# Altium schematic sheet 02_NRF52832.SchDoc
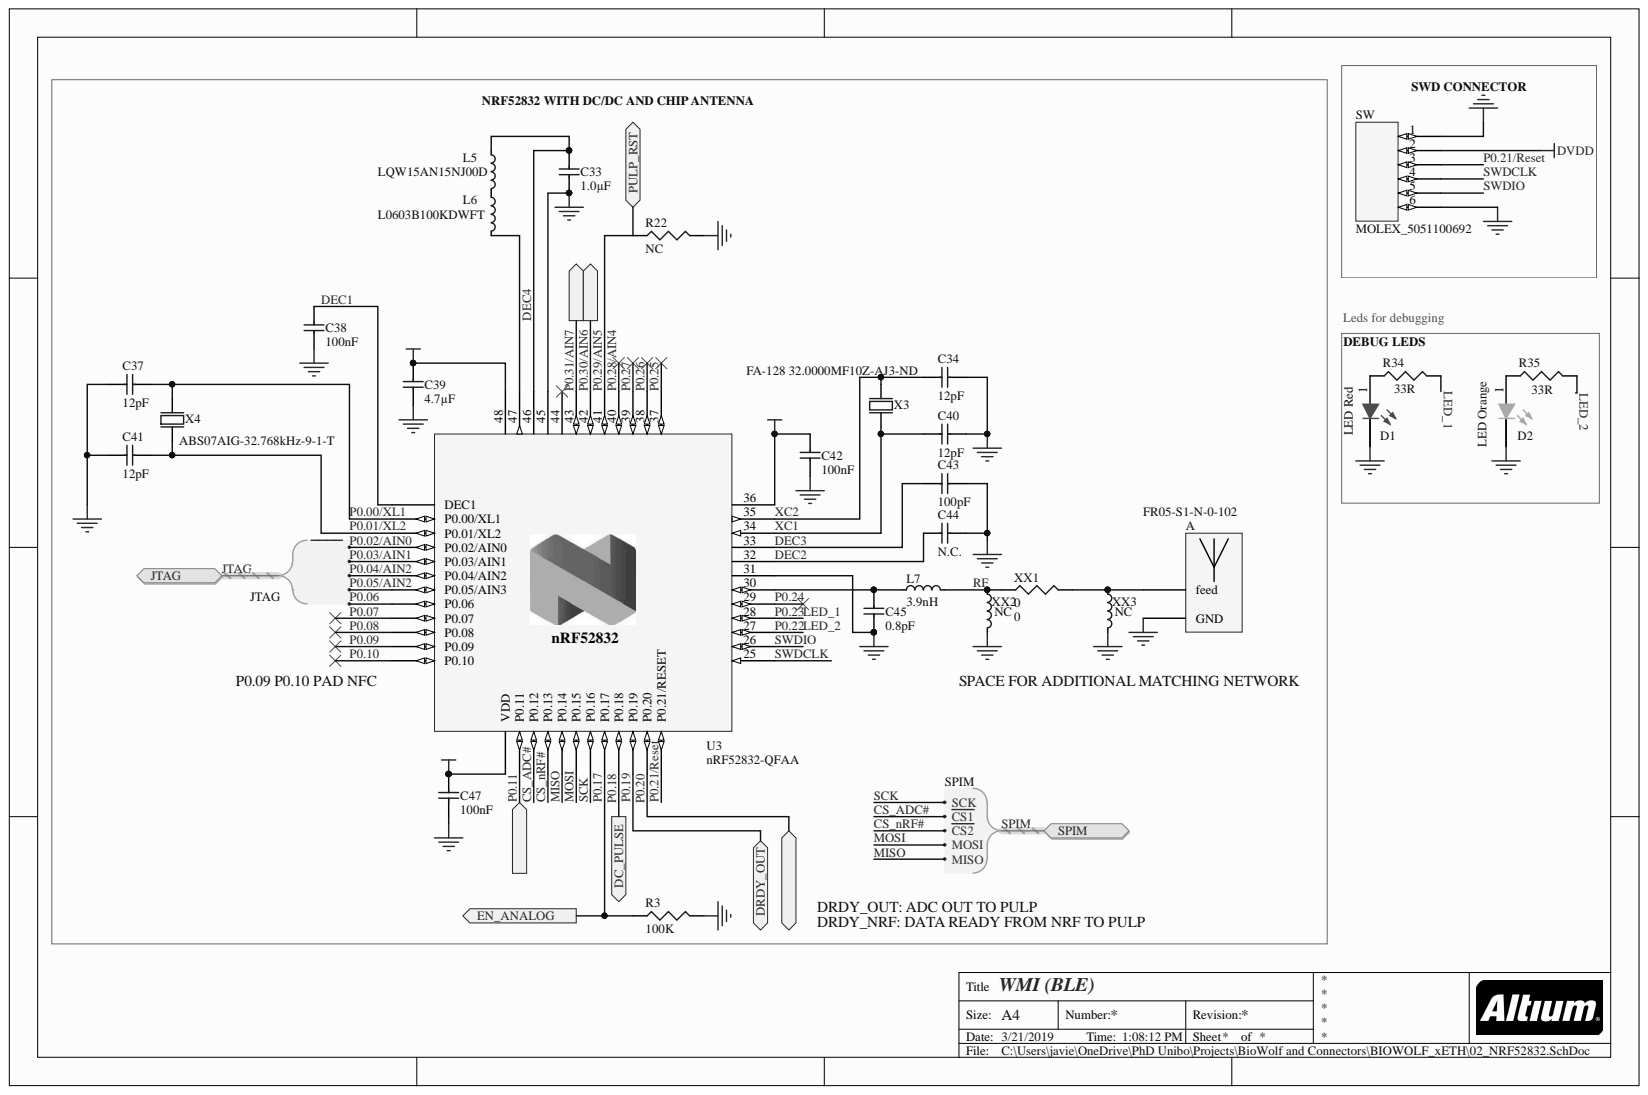
[diagram p0: 02_NRF52832.SchDoc]

## Parts
A  FR05-S1-N-0-102  [DFN510P700X220-2N]
C33  1.0µF  [CAPC1608X06L]
C34  12pF  [CAPC1005X04L]
C37  12pF  [CAPC1005X04L]
C38  100nF  [CAPC1005X04L]
C39  4.7µF  [CAPC1608X06L]
C40  12pF  [CAPC1005X04L]
C41  12pF  [CAPC1005X04L]
C42  100nF  [CAPC1005X04L]
C43  100pF  [CAPC1005X04L]
C44  N.C.  [CAPC1005X04L]
C45  0.8pF  [CAPC1005X04L]
C47  100nF  [CAPC1005X04L]
D1  LED Red  [DIOC1608X08L]
D2  LED Orange  [DIOC1608X08L]
L5  LQW15AN15NJ00D  [INDC1005X04L]
L6  L0603B100KDWFT  [J1-0603]
L7  3.9nH  [INDC1005X04L]
R3  100K  [0402]
R34  value 33R  [J1-0603]
R35  value 33R  [J1-0603]
SW  MOLEX_5051100692  [MOLEX_5051100692]
U3  nRF52832-QFAA  [QFN40P600X600X90-48N]
X3  FA-128 32.0000MF10Z-AJ3-ND  [BT-XTAL_2016]
X4  ABS07AIG-32.768kHz-9-1-T  [XTAL_3215]
XX1  0  [0402]
XX2  NC  [INDC1005X04L]
XX3  NC  [INDC1005X04L]

## Ports
DC_PULSE, DRDY#, DRDY_NRF, DRDY_OUT, EN_ANALOG, JTAG, JTAG.TCK, JTAG.TDI, JTAG.TDO, JTAG.TMS, JTAG.~{TRST}, PULP_RST, SCL, SDA, SPIM, SPIM.MISO, SPIM.MOSI, SPIM.SCK, SPIM.~{CS1}, SPIM.~{CS2}

## Nets
DVDD: C39.1 C42.1 C47.1 SW.2 U3.13(VDD) U3.36 U3.48
GND: A.3 C33.1 C34.2 C37.2 C38.2 C39.2 C40.2 C41.2 C42.2 C43.2 C44.1 C45.1 C47.2 D1.2 D2.2 R3.2 SW.1 SW.6 U3.31 U3.45 U3.49 X3.2 X3.4 XX2.2 XX3.2
CS_ADC#: U3.15(P0.12) ; port SPIM
CS_nRF#: U3.16(P0.13) ; port SPIM
DEC1: C38.1 U3.1(DEC1)
DEC2: C44.2 U3.32
DEC3: C43.1 U3.33
DEC4: C33.2 L5.1 U3.46
JTAG.~{TRST}: U3.4(P0.02/AIN0) ; port JTAG
JTAG.TCK: U3.8(P0.06) ; port JTAG
JTAG.TDI: U3.5(P0.03/AIN1) ; port JTAG
JTAG.TDO: U3.6(P0.04/AIN2) ; port JTAG
JTAG.TMS: U3.7(P0.05/AIN3) ; port JTAG
LED_1: R34.2 U3.28
LED_2: R35.2 U3.27
MISO: U3.17(P0.14) ; port SPIM
MOSI: U3.18(P0.15) ; port SPIM
P0.00/XL1: C37.1 U3.2(P0.00/XL1) X4.1
P0.01/XL2: C41.1 U3.3(P0.01/XL2) X4.2
P0.07: U3.9(P0.07)
P0.08: U3.10(P0.08)
P0.09: U3.11(P0.09)
P0.10: U3.12(P0.10)
P0.11: U3.14(P0.11) ; port DRDY#
P0.17: R3.1 U3.20(P0.17) ; port EN_ANALOG
P0.18: U3.21(P0.18) ; port DC_PULSE
P0.19: U3.22(P0.19) ; port DRDY_OUT
P0.20: U3.23(P0.20) ; port DRDY_NRF
P0.21/Reset: SW.3 U3.24(P0.21/RESET)
P0.24: U3.29
P0.25: U3.37
P0.26: U3.38
P0.27: U3.39
P0.28/AIN4: U3.40
P0.29/AIN5: U3.41 ; port PULP_RST
P0.30/AIN6: U3.42 ; port SDA
P0.31/AIN7: U3.43 ; port SCL
RF: L7.2 XX1.1 XX2.1
SCK: U3.19(P0.16) ; port SPIM
SWDCLK: SW.4 U3.25
SWDIO: SW.5 U3.26
XC1: C40.1 U3.34 X3.1
XC2: C34.1 U3.35 X3.3
NetA_1: A.1 XX1.2 XX3.1
NetC45_2: C45.2 L7.1 U3.30
NetD1_1: D1.1 R34.1
NetD2_1: D2.1 R35.1
NetL5_2: L5.2 L6.1
NetL6_2: L6.2 U3.47

Not connected: U3 44

## Library data of the parts
A = FR05-S1-N-0-102: Bluetooth antenna; Component Kind: Standard; Library Name: SchematicLibrary.SchLib
C33 = C0603-1µ0: Capacitor, X7R, ±10%; Artikkelnr Noca: 20039335; Artikkelnr Simpro: N.A.; Database Table Name: Capacitors$; Note: 16V; Component Kind: Standard; Footprint Doc: 0603; LatestRevisionDate: 2013-06-05; Library Name: Nordic Passive DB.DbLib; Part Number: C0603-1µ0; Pin Count: 2; Published: 2009-05-08; Value: 1.0µF; Publisher: Nordic Semiconductor
C34 C37 C40 C41 = C0402-12p: Capacitor, NP0, ±2%; Artikkelnr Noca: 20029159; Artikkelnr Simpro: N.A.; Database Table Name: Capacitors$; Note: 50V; Component Kind: Standard; Footprint Doc: 0402; LatestRevisionDate: 2011-05-11; Library Name: Nordic Passive DB.DbLib; Part Number: C0402-12p; Pin Count: 2; Published: 2009-05-08; Value: 12pF; Publisher: Nordic Semiconductor
C38 C42 C47 = C0402-100n: Capacitor, X7R, ±10%; Artikkelnr Noca: 20039200; Artikkelnr Simpro: N.A.; Database Table Name: Capacitors$; Note: 16V; Component Kind: Standard; Footprint Doc: 0402; LatestRevisionDate: 2009-11-23; Library Name: Nordic Passive DB.DbLib; Part Number: C0402-100n; Pin Count: 2; Published: 2009-05-08; Value: 100nF; Publisher: Nordic Semiconductor
C39 = C0603-4µ7: Capacitor, X5R, ±10%; Artikkelnr Noca: 20069060; Artikkelnr Simpro: N.A.; Database Table Name: Capacitors$; Note: 6.3V; Component Kind: Standard; Footprint Doc: 0603; LatestRevisionDate: 2009-11-23; Library Name: Nordic Passive DB.DbLib; Part Number: C0603-4µ7; Pin Count: 2; Published: 2009-05-08; Value: 4.7µF; Publisher: Nordic Semiconductor
C43 = C0402-100p: Capacitor, NP0, ±5%; Artikkelnr Noca: 20029135; Artikkelnr Simpro: N.A.; Database Table Name: Capacitors$; Note: 50V; Component Kind: Standard; Footprint Doc: 0402; LatestRevisionDate: 2009-05-08; Library Name: Nordic Passive DB.DbLib; Part Number: C0402-100p; Pin Count: 2; Published: 2009-05-08; Value: 100pF; Publisher: Nordic Semiconductor
C44 = C0402-N.C.: Not mounted; Artikkelnr Noca: N.A.; Database Table Name: Capacitors$; Note: Chip Cap; Artikkelnr Simpro: N.A.; Component Kind: Standard; Footprint Doc: 0402; LatestRevisionDate: 2009-05-08; Library Name: Nordic Passive DB.DbLib; Part Number: C0402-N.C.; Pin Count: 2; Published: 2009-05-08; Value: N.C.; Publisher: Nordic Semiconductor
C45 = C0402-0.8p: Capacitor, NP0, ±5%; Artikkelnr Noca: 20029147; Artikkelnr Simpro: N.A.; Database Table Name: Capacitors$; Note: 50V; Component Kind: Standard; Footprint Doc: 0402; LatestRevisionDate: 2012-11-21; Library Name: Nordic Passive DB.DbLib; Part Number: C0402-0.8p; Pin Count: 2; Published: 2009-05-08; Value: 0.8pF; Publisher: Nordic Semiconductor
D1 = KING-LED-RED-APT1608LSECK/J3-PRV: 1.6X0.8mm SMD CHIP LED LAMP, Hyper Red; Part Number: APT1608LSECK/J4-PRV; Forward Voltage: 1.8V; Test Current: 2mA; Component Kind: Standard; ComponentLink1Description: Datasheet; ComponentLink1URL: http://www.kingbrightusa.com/images/catalog/SPEC/APT1608LSECK-J3-PRV.pdf; Library Name: schematics.SchLib; Supplier 1: Digi-Key; Supplier 2: Mouser; Supplier 3: Digi-Key; Supplier 4: Digi-Key; Supplier Part Number 1: 754-1930-6-ND; Supplier Part Number 2: 604-APT1608LSECKJ3RV; Supplier Part Number 3: 754-1930-1-ND; Supplier Part Number 4: 754-1930-2-ND
D2 = KING-LED-ORANGE-APT1608LSECK/J4-PRV: 1.6X0.8mm SMD CHIP LED LAMP, Super Bright Orange; Forward Voltage: 1.8V; Test Current: 2mA; Component Kind: Standard; ComponentLink1Description: Datasheet; ComponentLink1URL: http://www.kingbrightusa.com/images/catalog/SPEC/APT1608LSECK-J4-PRV.pdf; Library Name: schematics.SchLib; Part Number: APT1608LSECK/J4-PRV; Supplier 1: Digi-Key; Supplier 2: Digi-Key; Supplier 3: Mouser; Supplier 4: Digi-Key; Supplier Part Number 1: 754-1931-6-ND; Supplier Part Number 2: 754-1931-1-ND; Supplier Part Number 3: 604-APT1608LSECKJ4RV; Supplier Part Number 4: 754-1931-2-ND
L5 = L0402-15n: High frequency chip inductor ±10%; 2nd source part number: 658-1328; Manufacturer: Taiyo Yuden; Manufacturer Part Number: HK100515NJ-T; Artikkelnr Noca: 33029323; Artikkelnr Simpro: N.A.; Database Table Name: Inductors$; Value: 15nH; 2nd source Supplier: Farnell; Component Kind: Standard; Datasheet: http://www.yuden.co.jp/productdata/catalog/en/mlci04_e.pdf; Footprint Doc: 0402; LatestRevisionDate: 2012-09-19; Library Name: Nordic Passive DB.DbLib; Note: High frequency chip inductor ±10%; Part Number: L0402-15n; Pin Count: 2; Published: 2010-07-12; Supplier: Digi-Key; Supplier part number: 587-1521-1-ND; Publisher: Nordic Semiconductor
L6 = L0603-10µ: Chip inductor, IDC,min = 50 mA, ±20%; 2nd source part number: 178-6105; Manufacturer: Taiyo Yuden; Manufacturer Part Number: LBMF1608T100K; Artikkelnr Noca: 33029314; Artikkelnr Simpro: N.A.; Database Table Name: Inductors$; Note: LBMF1608T100K 10µH 80mA 0603; Value: 10µH; 2nd source Supplier: Farnell; Component Kind: Standard; Datasheet: http://www.yuden.co.jp/ut/product/pdf/elb2_e.pdf; Footprint Doc: 0603; LatestRevisionDate: 2012-09-19; Library Name: Nordic Passive DB.DbLib; Part Number: L0603-10µ; Pin Count: 2; Published: 2010-02-24; Supplier: Digi-Key; Supplier part number: 587-1714-1-ND; Publisher: Nordic Semiconductor
L7 = L0402-3n9: High frequency chip inductor ±5%; Manufacturer: Murata; Manufacturer Part Number: LQP15MN3N9B02D; Artikkelnr Noca: 33029151; Artikkelnr Simpro: N.A.; Database Table Name: Inductors$; Component Kind: Standard; Datasheet: http://search.murata.co.jp/Ceramy/image/img/PDF/ENG/L0075S0084LQP15M.pdf; Footprint Doc: 0402; LatestRevisionDate: 2012-09-19; Library Name: Nordic Passive DB.DbLib; Note: High frequency chip inductor ±5%; Part Number: L0402-3n9; Pin Count: 2; Published: 2009-05-08; Supplier: Digi-Key; Supplier part number: 490-1131-1-ND; Value: 3.9nH; Publisher: Nordic Semiconductor
R3 XX1 = Res3: Resistor; Component Kind: Standard; LatestRevisionDate: 29-May-2009; LatestRevisionNote: IPC-7351 Footprint Added.; Library Name: Miscellaneous Devices.IntLib; PackageDescription: Chip Resistor; PackageReference: J1-0603; Published: 8-Jun-2000; Signal Integrity: Res; Simulation: RESISTOR; Publisher: Altium Limited
  R3: Value: 100K
  XX1: Value: 0
R34 R35 = Res3: Resistor; Value: 33R; Component Kind: Standard; LatestRevisionDate: 29-May-2009; LatestRevisionNote: IPC-7351 Footprint Added.; Library Name: Miscellaneous Devices.IntLib; PackageDescription: Chip Resistor; PackageReference: J1-0603; Published: 8-Jun-2000; Signal Integrity: Res; Simulation: RESISTOR; Publisher: Altium Limited
U3 = nRF52832-QFAB: Multi-protocol Bluetooth Low Energy, ANT and 2.4GHz proprietary system-on-chip; Manufacturer: Nordic Semiconductor; Artikkelnr Noca: N.A.; Database Table Name: nRF Devices$; Artikkelnr Simpro: N.A.; Component Kind: Standard; Footprint Doc: QFN-48; LatestRevisionDate: 2016-11-24; Library Name: Nordic Active DB.DbLib; Manufacturer Part Number: nRF52832-QFAA; Part Number: nRF52832-QFAA; Pin Count: 48; Published: 2016-11-24; Supplier: Nordic Semiconductor; Value: nRF52832-QFAA; Publisher: Nordic Semiconductor
X3 = XTAL_SMD2016_32MHz_8pF_General: XTAL SMD 2016, 32MHz, Cl=8pF, Total Tol: ±40ppm; Artikkelnr Noca: N.A.; Database Table Name: Crystal_Osc$; Note: XTAL SMD 32.000MHz; Artikkelnr Simpro: N.A.; Component Kind: Standard; Footprint Doc: XTAL_2016; LatestRevisionDate: 2016-08-19; Library Name: Nordic Discrete DB.DbLib; Part Number: XTAL_SMD2016_32MHz_8pF_General; Pin Count: 4; Published: 2016-08-19; Value: 32MHz; Publisher: Nordic Semiconductor
X4 = XTAL_SMD3215_32.768kHz_9pF_General: XTAL SMD 3215, 32.768kHz, Cl=9pF, Total Tol: ±50ppm; Artikkelnr Noca: N.A.; Database Table Name: Crystal_Osc$; Note: XTAL SMD 32.768kHz; Artikkelnr Simpro: N.A.; Component Kind: Standard; Footprint Doc: XTAL_3215; LatestRevisionDate: 2016-08-19; Library Name: Nordic Discrete DB.DbLib; Part Number: XTAL_SMD3215_32.768kHz_9pF_General; Pin Count: 2; Published: 2016-08-19; Value: 32.768kHz; Publisher: Nordic Semiconductor
XX2 XX3 = L0402-N.C.: Not mounted; Artikkelnr Noca: N.A.; Assembly: Not mounted; Database Table Name: Inductors$; Value: N.C.; Artikkelnr Simpro: N.A.; Component Kind: Standard; ComponentLink1Description: Datasheet; ComponentLink2Description: Supplier link; Footprint Doc: 0402; LatestRevisionDate: 2016-11-24; Library Name: Nordic Passive DB.DbLib; Part Number: L0402-N.C.; Pin Count: 2; Published: 2016-11-24; Publisher: Nordic Semiconductor
SW = MOLEX_5051100692: Component Kind: Standard; Library Name: Schlib2.SchLib
